# Altium schematic sheet Top.SchDoc(Top)
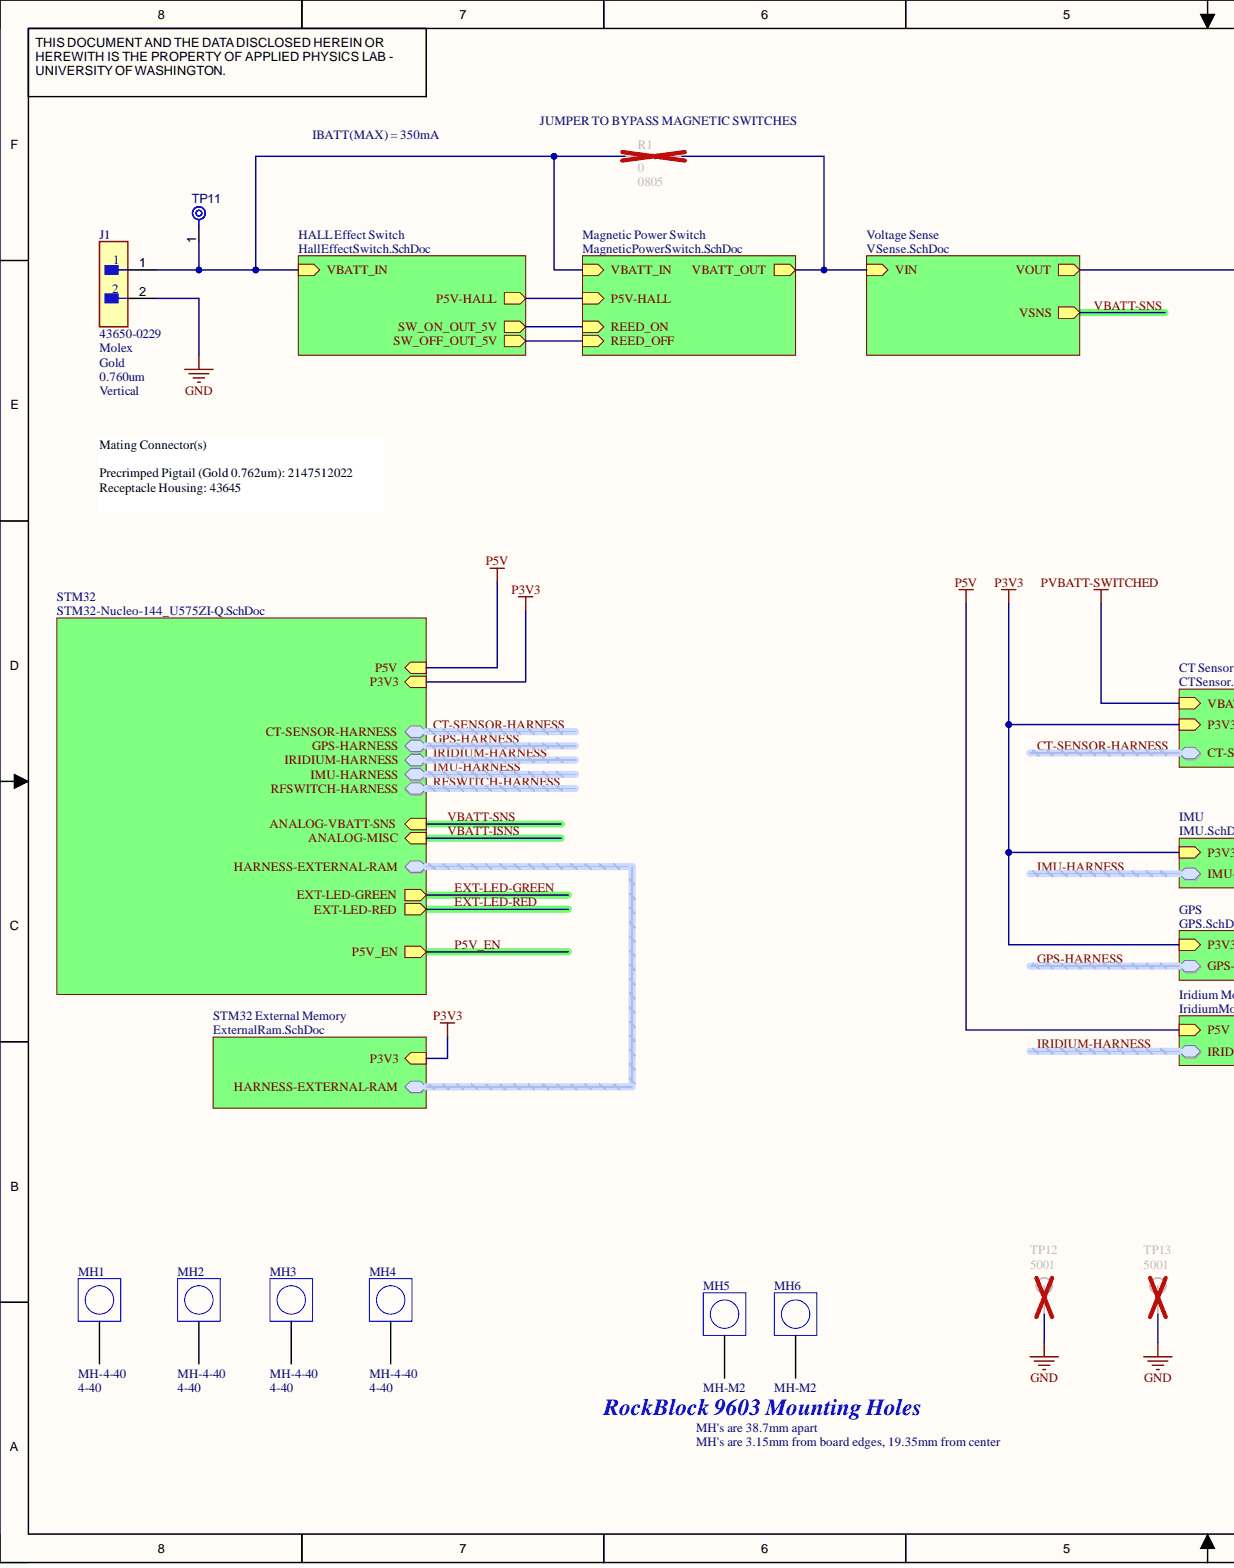
[diagram p0: Top.SchDoc, left]
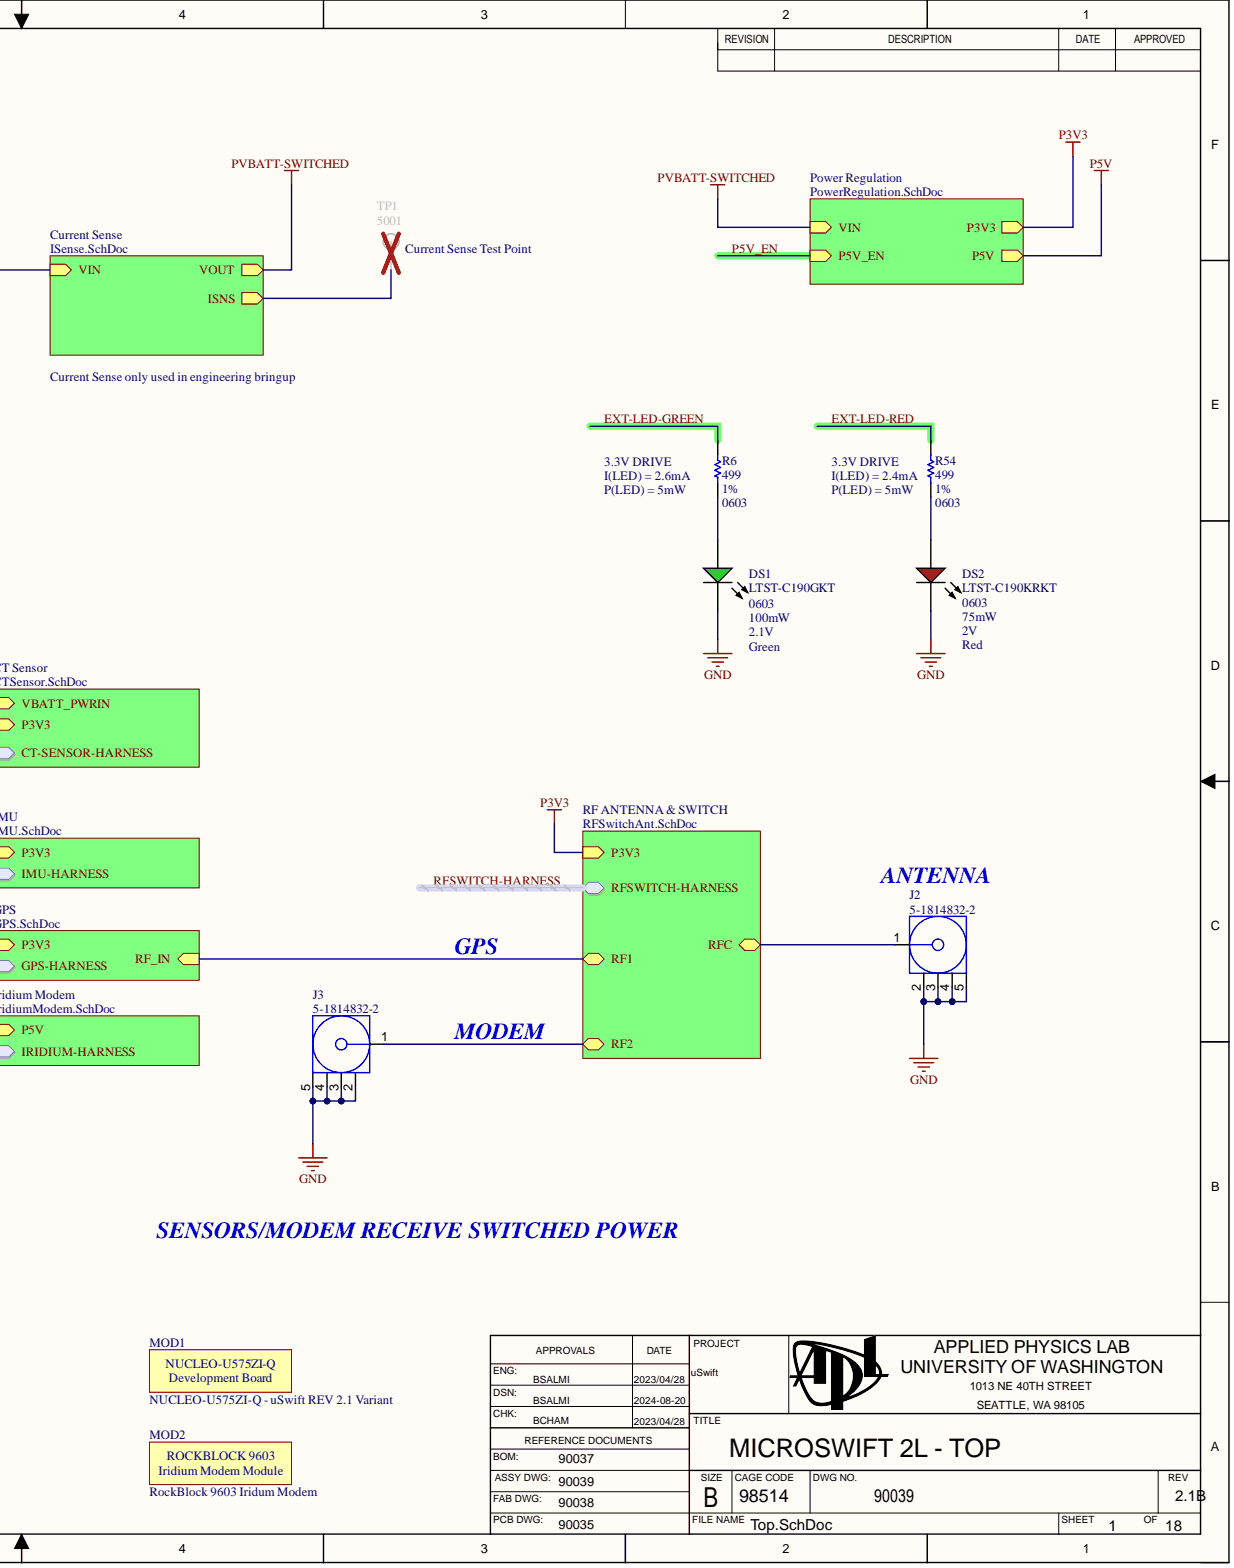
[diagram p1: Top.SchDoc, right]

## Parts
DS1  LTST-C190GKT  Case/Package: 0603  Colour: Green  Forward Voltage: 2.1V  Power: 100mW
DS2  LTST-C190KRKT  Case/Package: 0603  Colour: Red  Forward Voltage: 2V  Power: 75mW
J1  43650-0229  Contact Plating: Gold  Manufacturer: Molex
J2  5-1814832-2
J3  5-1814832-2
MH1  MH-4-40  Fastener Size: 4-40  (no BOM)
MH2  MH-4-40  Fastener Size: 4-40  (no BOM)
MH3  MH-4-40  Fastener Size: 4-40  (no BOM)
MH4  MH-4-40  Fastener Size: 4-40  (no BOM)
MH5  MH-M2  (no BOM)
MH6  MH-M2  (no BOM)
MOD1  NUCLEO-U575ZI-Q - uSwift REV 2.1 Variant
MOD2  RockBlock 9603 Iridum Modem
R1  value 0  Case/Package: 0805  (hidden comment: Resistor 0R +/- 5% 0805 125 mW)
R6  value 499  Case/Package: 0603  Tolerance: 1%  (hidden comment: Resistor 499R +/-1% 0603 100 mW)
R54  value 499  Case/Package: 0603  Tolerance: 1%  (hidden comment: Resistor 499R +/-1% 0603 100 mW)
TP1  5001
TP11  TPAD6R-CIRCLED  (value not drawn)  (no BOM)
TP12  5001
TP13  5001

## Nets
GND: DS1.2 DS2.2 J1.2 J2.2 J2.3 J2.4 J2.5 J3.2 J3.3 J3.4 J3.5 TP12.1 TP13.1
EXT-LED-GREEN: R6.1  [also labelled PF]
EXT-LED-RED: R54.1  [also labelled PF]
NetDS1_1: DS1.1 R6.2
NetDS2_1: DS2.1 R54.2
NetJ1_1: J1.1 R1.1 TP11.1
NetJ2_1: J2.1
NetJ3_1: J3.1
NetMH1_1: MH1.1
NetMH2_1: MH2.1
NetMH3_1: MH3.1
NetMH4_1: MH4.1
NetMH5_1: MH5.1
NetMH6_1: MH6.1
NetR1_2: R1.2
NetTP1_1: TP1.1
CT-SENSOR-HARNESS~{.UART-FORCEOFF}:
CT-SENSOR-HARNESS~{.UART-INVALID}:
CT-SENSOR-HARNESS.CT-PWR-EN:  [also labelled PG]
CT-SENSOR-HARNESS.MCU_UART_RXD:  [also labelled PC]
CT-SENSOR-HARNESS.MCU_UART_TXD:  [also labelled PC]
GPS-HARNESS.GNSS-EXTINT:  [also labelled PG]
GPS-HARNESS.GNSS-PWR-EN:  [also labelled PG]
GPS-HARNESS.GNSS-TIMEPULSE:
GPS-HARNESS.MCU_GNSS-UART-RX:  [also labelled PA]
GPS-HARNESS.MCU_GNSS-UART-TX:  [also labelled PA]
HARNESS-EXTERNAL-RAM~{.EXT-RAM-CE}:
HARNESS-EXTERNAL-RAM.EXT-RAM-1-SIO0:
HARNESS-EXTERNAL-RAM.EXT-RAM-1-SIO1:
HARNESS-EXTERNAL-RAM.EXT-RAM-1-SIO2:
HARNESS-EXTERNAL-RAM.EXT-RAM-1-SIO3:
HARNESS-EXTERNAL-RAM.EXT-RAM-2-SIO0:
HARNESS-EXTERNAL-RAM.EXT-RAM-2-SIO1:
HARNESS-EXTERNAL-RAM.EXT-RAM-2-SIO2:
HARNESS-EXTERNAL-RAM.EXT-RAM-2-SIO3:
HARNESS-EXTERNAL-RAM.EXT-RAM-SCLK:
IMU-HARNESS.I2C_SCL:  [also labelled PG]
IMU-HARNESS.I2C_SDA:  [also labelled PG]
IMU-HARNESS.IMU-INT:  [also labelled PG]
IMU-HARNESS.IMU-NRESET:  [also labelled PG]
IMU-HARNESS.IMU-PWR-EN:  [also labelled PD]
IRIDIUM-HARNESS.IRIDIUM-PWR-EN:  [also labelled PD]
IRIDIUM-HARNESS.IRIDIUM_CTS:
IRIDIUM-HARNESS.IRIDIUM_RTS:
IRIDIUM-HARNESS.MCU_IRIDIUM_RXD:  [also labelled PD]
IRIDIUM-HARNESS.MCU_IRIDIUM_TXD:  [also labelled PC]
IRIDIUM-HARNESS.NETWORK_AVAILABLE:  [also labelled PD]
IRIDIUM-HARNESS.RING_INDICATOR:  [also labelled PD]
IRIDIUM-HARNESS.SLEEP_CONTROL:  [also labelled PD]
P3V3:
P5V:
P5V-HALL:
P5V_EN:  [also labelled PF]
PVBATT-SWITCHED:
REED_OFF:
REED_ON:
RF1:
RFSWITCH-HARNESS.PWR-EN:  [also labelled PD]
RFSWITCH-HARNESS.VCTL:  [also labelled PD]
VBATT-ISNS:  [also labelled PF]
VBATT-SNS:  [also labelled PF]
VIN:

## Library data of the parts
DS1 = CMP-007-00022-3: LED Uni-Color Green, 100 mW, 30 mA, -55 to 85 degC, 2-Pin SMD, RoHS, Tape and Reel; Manufacturer: Lite-On; Forward Current: 20mA; Lens Style: Clear; Luminous Intensity: 6mcd; Viewing Angle: 130degrees; Component Kind: Standard; Datasheet URL: http://datasheet.octopart.com/LTST-C190GKT-Lite-On-datasheet-158530.pdf; Datasheet Version: Dec-06; Illumination Colour: Green; Manufacturer URL: http://www.us.liteon.com/; Max Operating Temperature: 85°C; Min Operating Temperature: -55°C; Mount: Surface Mount; Nominal Vf: 2.1V; Package Description: 2-Pin Surface Mount Device, Body 1.6 x 0.8 mm; Package Quantity: 3000; Package Reference: C190GKT; Packaging: TapeandReel; Pins: 2; RoHS Compliant: True; Library Name: Applied Physics Lab
DS2 = CMP-007-00024-3: LED Uni-Color Red, 62.5 mW, 25 mA, -30 to 85 degC, 2-Pin SMD, RoHS, Tape and Reel; Manufacturer: Lite-On; Forward Current: 20mA; Lens Style: Diffused; Luminous Intensity: 54mcd; Viewing Angle: 130degrees; Component Kind: Standard; Datasheet URL: http://optoelectronics.liteon.com/upload/download/DS-22-99-0151/S_110_LTST-C190KRKT.pdf; Datasheet Version: Rev. F, 05/2013; Illumination Colour: Red; Manufacturer URL: http://www.us.liteon.com/; Max Operating Temperature: 85°C; Min Operating Temperature: -55°C; Mount: Surface Mount; Nominal Vf: 2V; Package Description: 2-Pin Surface Mount Device, Body 1.6 x 0.8 mm; Package Quantity: 3000; Package Reference: C191KRKT; Packaging: TapeandReel; Pins: 2; RoHS Compliant: True; Library Name: Applied Physics Lab
J1 = CMP-002-00120-6: Conn Wire to Board HDR 2 POS 3mm Solder ST Top Entry Thru-Hole Micro-Fit 3.0 Tray; __ORIG_LIFECYCLESTATEGUID__: 706004B5-C76E-482E-903B-BA0E4FAE086A; Circuits: 2; Connector Type: Male Pin; Gender: Male; Max Current Rating: 7A; Max Voltage Rating (DC): 600V; Pitch: 3.00mm; Plating Thickness: 0.760um; Rows: 1; Temperature - High: +125; Temperature - Low: -40; Case/Package: Header; Color: Black; Component Kind: Standard; Contact Type: Male Pin; Design Item ID: 43650-0229; Housing Material: Thermoplastic; Max Operating Temperature: 125C; Min Operating Temperature: -40C; Mount: Through Hole; Number of Circuits: 2; Number of Contacts: 2; Number of Positions: 2; Number of Rows: 1; Orientation: Vertical; Pin Count: 2; Series: Micro-Fit 3.0 43650; Termination: Through Hole; Library Name: Applied Physics Lab
J2 J3 = CMP-002-00119-5: SMA Connector Jack, Female Socket 50Ohm Through Hole Solder; Manufacturer: TE Connectivity; Connector Type: RF&Coax; Case/Package: SMA; Component Kind: Standard; Gender: Female; Mount: Through Hole; Library Name: Applied Physics Lab
MH1-MH4 = CMP-00028-00017-3: 4-40 plated mounting hole with button head pad.; Plated: Yes; Mechanical Type: Mounting Hole; Library Name: Applied Physics Lab
MH5 MH6 = CMP-00028-00012-4: M2 plated mounting hole.; Plated: Yes; Fastener Size: M2; Mechanical Type: Mounting Hole; Library Name: Applied Physics Lab
MOD1 = CMP-001-0007-2: Component Kind: Standard; Description: NUCLEO-U575ZI-Q - uSwift REV 2.1 Variant; Library Name: Applied Physics Lab
MOD2 = CMP-001-0008-2: RockBlock 9603 Iridium Modem; Component Kind: Standard; Library Name: Applied Physics Lab
R1 = CMP-009-00007-7: Chip Resistor, 0 Ohm, 0.125 W, -55 to 155 degC, 0805 (2012 Metric); Power: 125mW; Voltage Rating: 50V; Component Kind: Standard; Decade: 0; Max Operating Temperature: 155°C; Min Operating Temperature: -55°C; Mount: Surface Mount; Pins: 2; RoHS Compliant: True; Tolerance: 5%; Library Name: Applied Physics Lab
R6 R54 = CMP-009-00760-5: Chip Resistor, 499 Ohm, +/- 1%, 0.1 W, -55 to 155 degC, 0603 (1608 Metric); Decade: 100; Power: 100mW; Voltage Rating: 75V; Component Kind: Standard; Max Operating Temperature: 155°C; Min Operating Temperature: -55°C; Mount: Surface Mount; Pins: 2; RoHS Compliant: Yes; Library Name: Applied Physics Lab
TP1 TP12 TP13 = CMP-001-0002-6: TEST POINT PC MINI .040D BLACK; Color: Black; Component Kind: Standard; Contact Material: Bronze; Contact Plating: Silver,Tin; Height: 0.3inch; Material: Nylon,Bronze,Silver; Mount: Through Hole; Series: THM; Library Name: Applied Physics Lab
TP11 = CMP-00028-00014-3: 0.6mm round with a silkscreen circle.; Pins: 1; Library Name: Applied Physics Lab
